# Altium schematic sheet M8TQFP2DIP.SchDoc(M8TQFP2DIP)
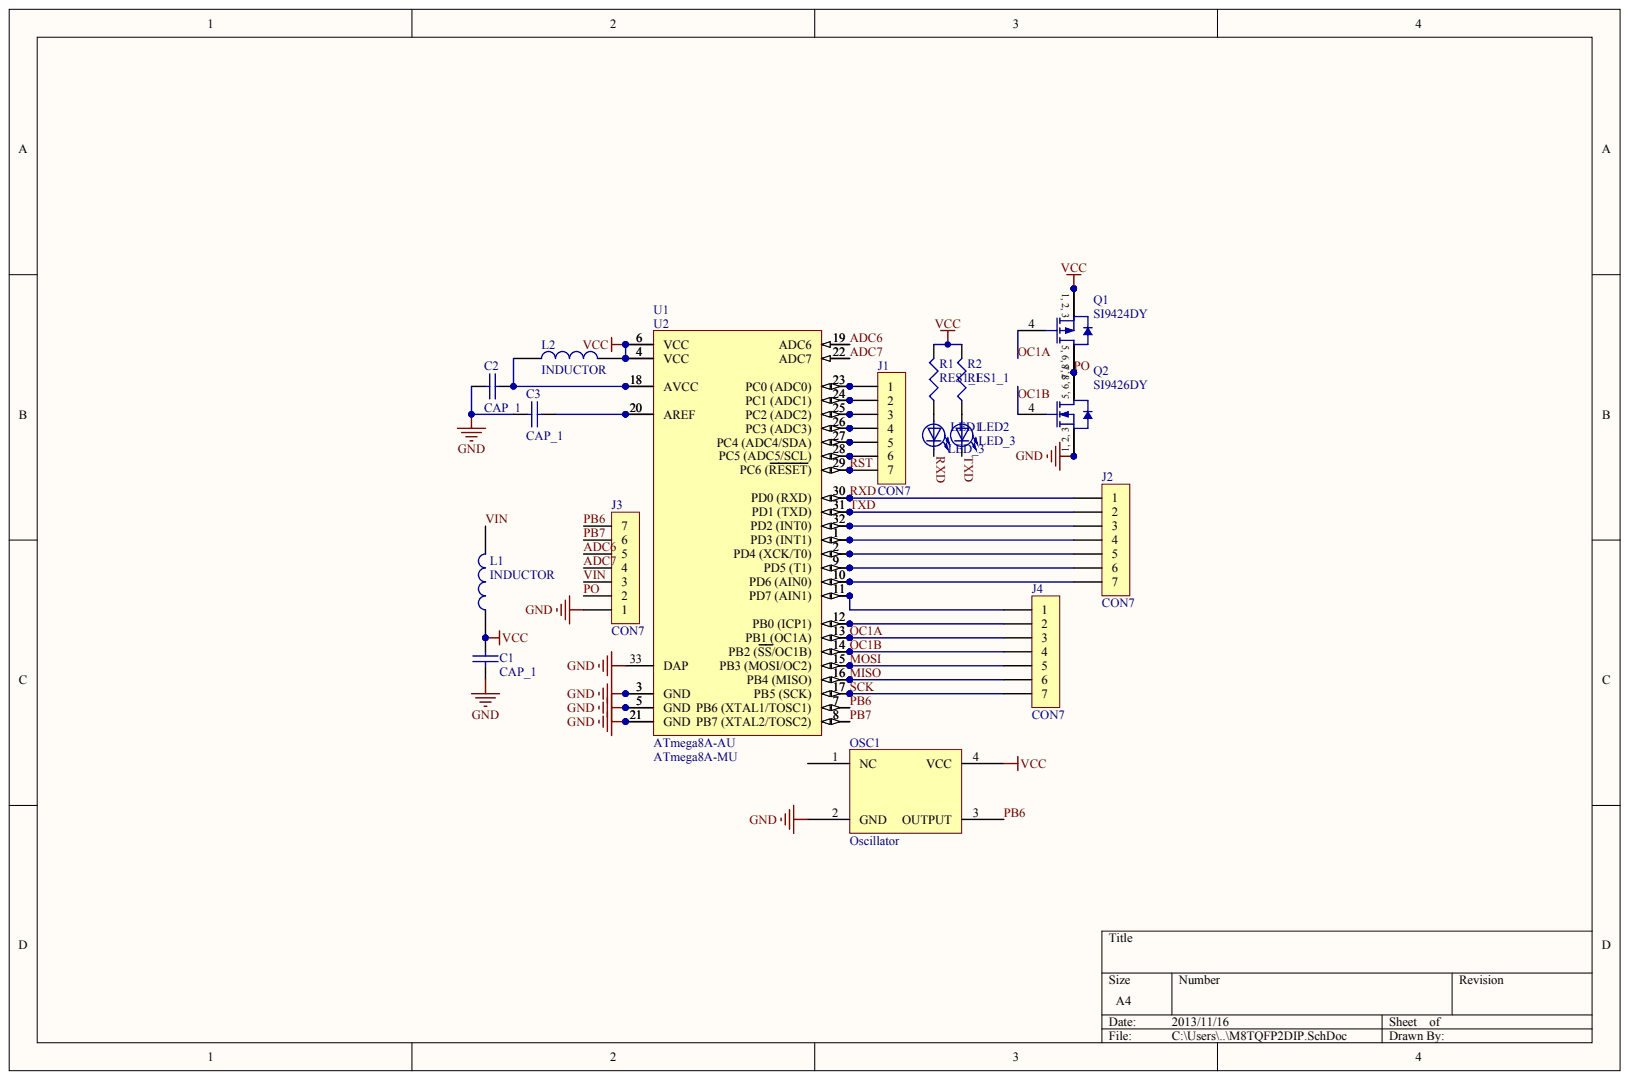
[diagram p0: M8TQFP2DIP.SchDoc]

## Parts
C1  CAP_1
C2  CAP_1
C3  CAP_1
J1  CON7
J2  CON7
J3  CON7
J4  CON7
L1  INDUCTOR
L2  INDUCTOR
LED1  LED_3
LED2  LED_3
OSC1  Oscillator
Q1  SI9424DY
Q2  SI9426DY
R1  RES1_1
R2  RES1_1
U1  ATmega8A-MU
U2  ATmega8A-AU

## Nets
GND: C1.1 C2.1 C3.1 J3.1 OSC1.2(GND) Q2.1 Q2.2 Q2.3 U1.3(GND) U1.5(GND) U1.21(GND) U2.3(GND) U2.5(GND) U2.21(GND) U2.33(DAP)
VCC: C1.2 L1.1 L2.2 OSC1.4(VCC) Q1.1 Q1.2 Q1.3 R1.2 R2.2 U1.4(VCC) U1.6(VCC) U2.4(VCC) U2.6(VCC)
ADC6: J3.5 U1.19(ADC6) U2.19(ADC6)
ADC7: J3.4 U1.22(ADC7) U2.22(ADC7)
MISO: J4.6 U1.16(PB4_(MISO)) U2.16(PB4_(MISO))
MOSI: J4.5 U1.15(PB3_(MOSI/OC2)) U2.15
OC1A: J4.3 Q1.4 U1.13(PB1_(OC1A)) U2.13(PB1_(OC1A))
OC1B: J4.4 Q2.4 U1.14(PB2_(SS/OC1B)) U2.14(PB2_(SS/OC1B))
PB6: J3.7 OSC1.3(OUTPUT) U1.7 U2.7
PB7: J3.6 U1.8 U2.8
PO: J3.2 Q1.5 Q1.6 Q1.7 Q1.8 Q2.5 Q2.6 Q2.7 Q2.8
RST: J1.7 U1.29(PC6_(RESET)) U2.29(PC6_(RESET))
RXD: J2.1 LED1.2 U1.30(PD0_(RXD)) U2.30(PD0_(RXD))
SCK: J4.7 U1.17(PB5_(SCK)) U2.17(PB5_(SCK))
TXD: J2.2 LED2.2 U1.31(PD1_(TXD)) U2.31(PD1_(TXD))
VIN: J3.3 L1.2
NetC2_2: C2.2 L2.1 U1.18(AVCC) U2.18(AVCC)
NetC3_2: C3.2 U1.20(AREF) U2.20(AREF)
NetJ1_1: J1.1 U1.23(PC0_(ADC0)) U2.23(PC0_(ADC0))
NetJ1_2: J1.2 U1.24(PC1_(ADC1)) U2.24(PC1_(ADC1))
NetJ1_3: J1.3 U1.25(PC2_(ADC2)) U2.25(PC2_(ADC2))
NetJ1_4: J1.4 U1.26(PC3_(ADC3)) U2.26(PC3_(ADC3))
NetJ1_5: J1.5 U1.27(PC4_(ADC4/SDA)) U2.27(PC4_(ADC4/SDA))
NetJ1_6: J1.6 U1.28(PC5_(ADC5/SCL)) U2.28(PC5_(ADC5/SCL))
NetJ2_3: J2.3 U1.32(PD2_(INT0)) U2.32(PD2_(INT0))
NetJ2_4: J2.4 U1.1(PD3_(INT1)) U2.1(PD3_(INT1))
NetJ2_5: J2.5 U1.2(PD4_(XCK/T0)) U2.2(PD4_(XCK/T0))
NetJ2_6: J2.6 U1.9(PD5_(T1)) U2.9(PD5_(T1))
NetJ2_7: J2.7 U1.10(PD6_(AIN0)) U2.10(PD6_(AIN0))
NetJ4_1: J4.1 U1.11(PD7_(AIN1)) U2.11(PD7_(AIN1))
NetJ4_2: J4.2 U1.12(PB0_(ICP1)) U2.12(PB0_(ICP1))
NetLED1_1: LED1.1 R1.1
NetLED2_1: LED2.1 R2.1

Not connected: OSC1 1(NC)

## Library data of the parts
C1-C3 J1-J4 L1 L2 LED1 LED2 OSC1 Q1 Q2 R1 R2 U1 U2 = (no symbol name)
  Q1: Published: 8-Jun-2000; LatestRevisionDate: 13-Apr-2006; LatestRevisionNote: IPC-7351 Footprint Added.; DatasheetVersion: 11-Jan-1999; PackageVersion: Aug-1998; PackageReference: SO-8; PackageDescription: 8-Pin Small Outline Integrated Circuit 1.27 mm Pitch; ComponentLink1Description: Manufacturer Link; ComponentLink1URL: http://www.vishay.com/
  Q2: Published: 8-Jun-2000; LatestRevisionDate: 13-Apr-2006; LatestRevisionNote: IPC-7351 Footprint Added.; DatasheetVersion: 2-Feb-1998; PackageVersion: Aug-1998; PackageReference: SO-8; PackageDescription: 8-Pin Small Outline Integrated Circuit 1.27 mm Pitch; ComponentLink1Description: Manufacturer Link; ComponentLink1URL: http://www.vishay.com/; ComponentLink2Description: Datasheet; ComponentLink2URL: http://www.vishay.com/docs/70160/70160.pdf
  U1: Published: 1-Apr-2010; DatasheetVersion: revC, Jul-2009; PackageVersion: revE, May-2006; PackageReference: 32M1-A; PackageDescription: 32-Pin Micro Lead Frame Package (MLF),  5 x 5 x 1.0 mm Body, Pitch 0.50 mm, 3.10mm Exposed Pad; Supplier 1: Digi-Key; Supplier Part Number 1: ATMEGA8A-MU-ND; Supplier 2: Farnell; Supplier Part Number 2: 1748533; Supplier 3: Mouser; Supplier Part Number 3: 556-ATMEGA8A-MU; Code_JEDEC: MO-220-VHHD-2; ComponentLink1Description: Manufacturer Link; ComponentLink1URL: http://www.atmel.com/products/avr/default.asp?; ComponentLink2Description: ATmega8A Datasheet; ComponentLink2URL: http://www.atmel.com/dyn/resources/prod_documents/doc8159.pdf; ComponentLink3Description: ATmega8A Summary; ComponentLink3URL: http://www.atmel.com/dyn/resources/prod_documents/8159S.pdf; ComponentLink4Description: Atmel.com Home; ComponentLink4URL: http://www.atmel.com
  U2: Published: 1-Apr-2010; DatasheetVersion: revC, Jul-2009; PackageVersion: revB, Oct-2001; PackageReference: 32A; PackageDescription: 32-Lead, 7x7x1.0 mm Body, 0.8mm Lead Pitch, Thin Profile Plastic Quad Flat Package (TQFP); Supplier 1: Digi-Key; Supplier Part Number 1: ATMEGA8A-AU-ND; Supplier 2: Farnell; Supplier Part Number 2: 1748532; Supplier 3: Mouser; Supplier Part Number 3: 556-ATMEGA8A-AU; Code_JEDEC: MS-026-ABA; ComponentLink1Description: Manufacturer Link; ComponentLink1URL: http://www.atmel.com/products/avr/default.asp?; ComponentLink2Description: ATmega8A Datasheet; ComponentLink2URL: http://www.atmel.com/dyn/resources/prod_documents/doc8159.pdf; ComponentLink3Description: ATmega8A Summary; ComponentLink3URL: http://www.atmel.com/dyn/resources/prod_documents/8159S.pdf; ComponentLink4Description: Atmel.com Home; ComponentLink4URL: http://www.atmel.com
  Q1 Q2 U1 U2: Publisher: Altium Limited
